# Altium schematic sheet MB1897.SchDoc(MB1897)
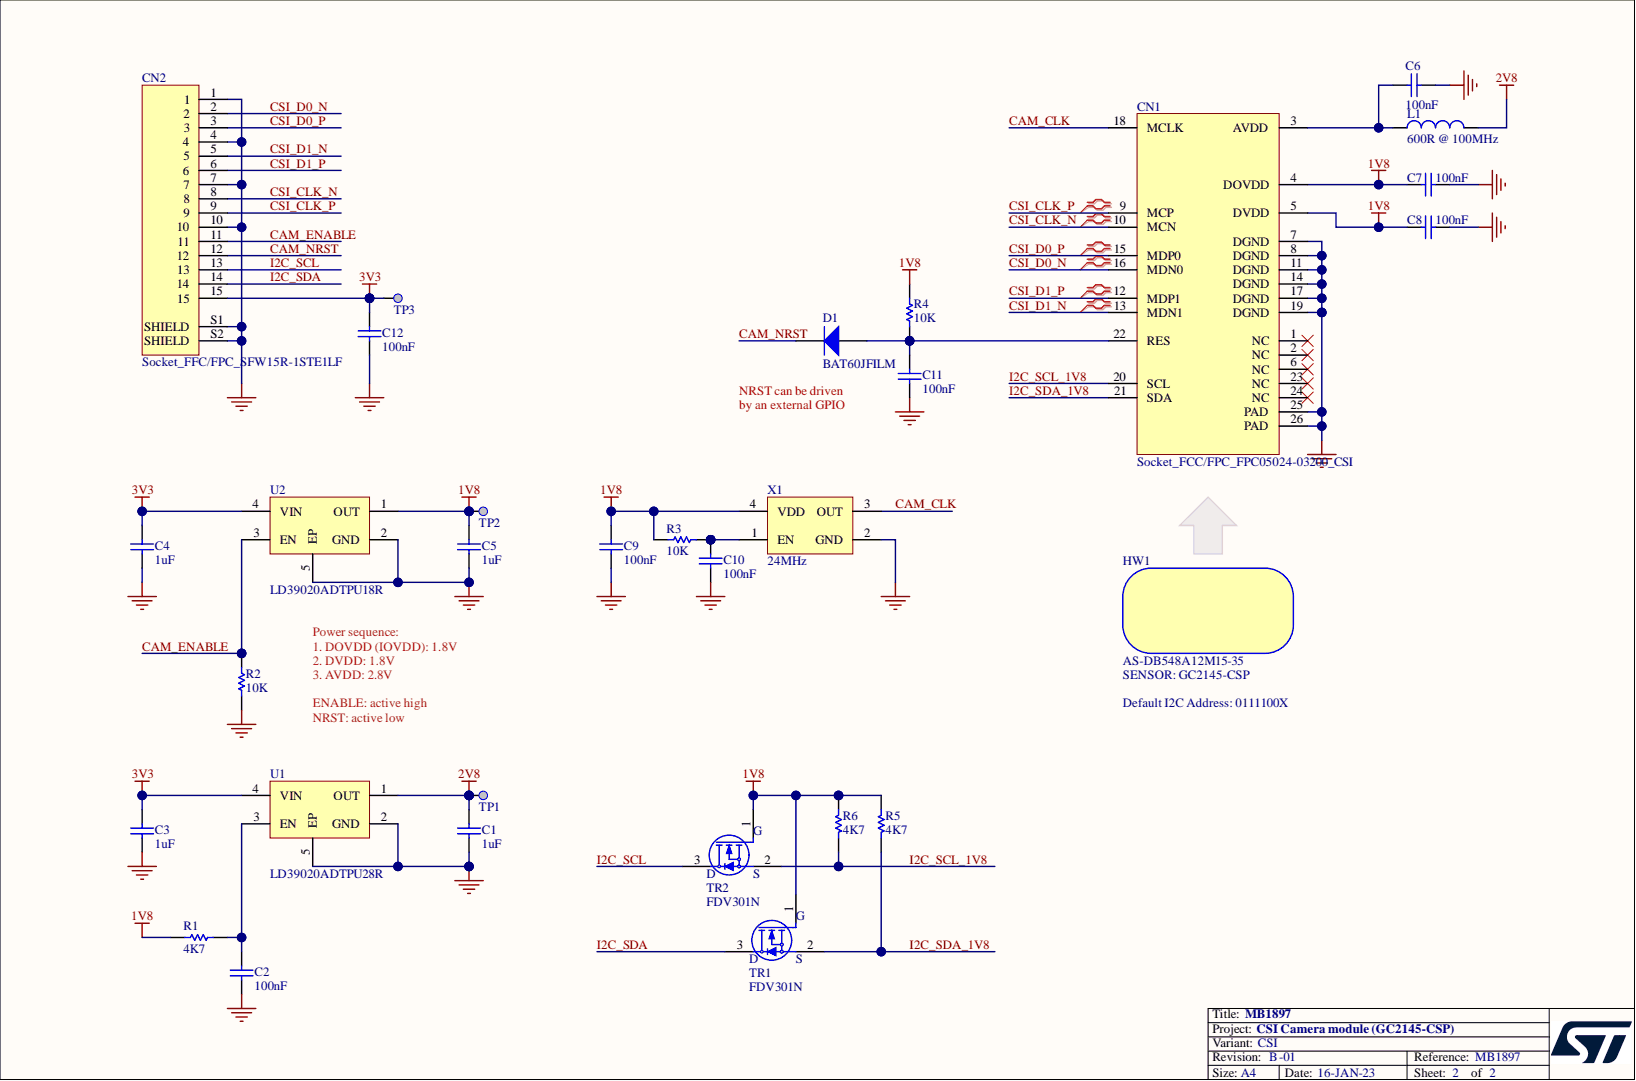
[diagram p0: MB1897.SchDoc]

## Parts
C1  value 1uF  [C0402]  (hidden comment: Capacitor)
C2  value 100nF  [C0402]  (hidden comment: Capacitor)
C3  value 1uF  [C0402]  (hidden comment: Capacitor)
C4  value 1uF  [C0402]  (hidden comment: Capacitor)
C5  value 1uF  [C0402]  (hidden comment: Capacitor)
C6  value 100nF  [C0402]  (hidden comment: Capacitor)
C7  value 100nF  [C0402]  (hidden comment: Capacitor)
C8  value 100nF  [C0402]  (hidden comment: Capacitor)
C9  value 100nF  [C0402]  (hidden comment: Capacitor)
C10  value 100nF  [C0402]  (hidden comment: Capacitor)
C11  value 100nF  [C0402]  (hidden comment: Capacitor)
C12  value 100nF  [C0402]  (hidden comment: Capacitor)
CN1  FPC05024-03200_CSI  value Socket_FCC/FPC_FPC05024-03200_CSI  [CON_ATOM_FPC05024-03200_INV]
CN2  SFW15R-1STE1LF  value Socket_FFC/FPC_SFW15R-1STE1LF  [CON_FCI_SFW15R-1STE1LF]
D1  BAT60JFILM  [DIO_SOD323]
HW1  value AS-DB548A12M15-35  Manufacturer_Part_Number: AS-DB548A12M15-35  (hidden comment: Camera_Module)
L1  value 600R @ 100MHz  [L0603]  (hidden comment: BEAD)
R1  value 4K7  [R0402]  (hidden comment: Resistor)
R2  value 10K  [R0402]  (hidden comment: Resistor)
R3  value 10K  [R0402]  (hidden comment: Resistor)
R4  value 10K  [R0402]  (hidden comment: Resistor)
R5  value 4K7  [R0402]  (hidden comment: Resistor)
R6  value 4K7  [R0402]  (hidden comment: Resistor)
TP1  Test Point  [TP_SMD_1MM]  (value not drawn)  (no BOM)
TP2  Test Point  [TP_SMD_1MM]  (value not drawn)  (no BOM)
TP3  Test Point  [TP_SMD_1MM]  (value not drawn)  (no BOM)
TR1  value FDV301N  Manufacturer_Part_Number: FDV301N  [MOS_SOT23]  (hidden comment: BSN20)
TR2  value FDV301N  Manufacturer_Part_Number: FDV301N  [MOS_SOT23]  (hidden comment: BSN20)
U1  LD39020  value LD39020ADTPU28R  Manufacturer_Part_Number: LD39020ADTPU28R  [REG_XDFN4-EPAD_CASE-711AJ_1X1_H0P4_P065]
U2  LD39020  value LD39020ADTPU18R  Manufacturer_Part_Number: LD39020ADTPU18R  [REG_XDFN4-EPAD_CASE-711AJ_1X1_H0P4_P065]
X1  value 24MHz  [CRY_NZ2016SH_4P]  (hidden comment: OSCILLATOR_4_PINS)

## Nets
1V8: C5.2 C7.1 C8.2 C9.2 CN1.4(DOVDD) CN1.5(DVDD) R1.1 R3.1 R4.2 R5.2 R6.2 TP2.1 TR1.1 TR2.1 U2.1(OUT) X1.4(VDD)
2V8: C1.2 L1.2 TP1.1 U1.1(OUT)
3V3: C3.2 C4.2 C12.2 CN2.15 TP3.1 U1.4(VIN) U2.4(VIN)
GND: C1.1 C2.1 C3.1 C4.1 C5.1 C6.2 C7.2 C8.1 C9.1 C10.1 C11.1 C12.1 CN1.7(DGND) CN1.8(DGND) CN1.11(DGND) CN1.14(DGND) CN1.17(DGND) CN1.19(DGND) CN1.25(PAD) CN1.26(PAD) CN2.1 CN2.4 CN2.7 CN2.10 CN2.S1(SHIELD) CN2.S2(SHIELD) R2.2 U1.2(GND) U1.5(EP) U2.2(GND) U2.5(EP) X1.2(GND)
CAM_CLK: CN1.18(MCLK) X1.3(OUT)
CAM_ENABLE: CN2.11 R2.1 U2.3(EN)
CAM_NRST: CN2.12 D1.2
CSI_CLK_N: CN1.10(MCN) CN2.8
CSI_CLK_P: CN1.9(MCP) CN2.9
CSI_D0_N: CN1.16(MDN0) CN2.2
CSI_D0_P: CN1.15(MDP0) CN2.3
CSI_D1_N: CN1.13(MDN1) CN2.5
CSI_D1_P: CN1.12(MDP1) CN2.6
I2C_SCL: CN2.13 TR2.3
I2C_SCL_1V8: CN1.20(SCL) R6.1 TR2.2
I2C_SDA: CN2.14 TR1.3
I2C_SDA_1V8: CN1.21(SDA) R5.1 TR1.2
NetC2_2: C2.2 R1.2 U1.3(EN)
NetC6_1: C6.1 CN1.3(AVDD) L1.1
NetC10_2: C10.2 R3.2 X1.1(EN)
NetC11_2: C11.2 CN1.22(RES) D1.1 R4.1

Not connected: CN1 1(NC) 2(NC) 6(NC) 23(NC) 24(NC)

## Library data of the parts
C1 C3-C5 = MCD-01722: Capacitor, MLCC, 1uF, 10V, X5R, +/-10%, 0402, T=0.5mm; Manufacturer: YAGEO; Manufacturer_Part_Number: CC0402KRX5R6BB105; Part_Preference: Recommended; Component_Country_Of_Origin: China; Database Table Name: MCD_PARTS$; ID: 3822; ShowFields: YES; Component Kind: Standard; ComponentLink1URL: http://tunmcdsvn.tun.st.com/Component_Documentation_Library/Trunk/Capacitor/YAGEO_X5R_Series; Internal_Part_Number: MCD-01722; Library Name: MCD_PARTS_database.DbLib; Order_Code_Digikey: 311-1439-1-ND; Order_Code_Farnell: 1458896; Order_Code_RS: N/A; Package: 0402; Part_Type: Capacitor; Status: Released
C2 C6-C12 = MCD-00006: Capacitor, MLCC, 100nF, 16V, X7R, +/-10%, 0402, T=0.5mm; Manufacturer: YAGEO; Manufacturer_Part_Number: CC0402KRX7R7BB104; Part_Preference: Recommended; Component_Country_Of_Origin: China; Database Table Name: MCD_PARTS$; ID: 2474; Order_Code_RS: 616-9701; ShowFields: YES; Component Kind: Standard; ComponentLink1URL: http://tunmcdsvn.tun.st.com/Component_Documentation_Library/Trunk/Capacitor/YAGEO_X7R_Series; Internal_Part_Number: MCD-00006; Library Name: MCD_PARTS_database.DbLib; Order_Code_Digikey: 311-1338-1-ND; Order_Code_Farnell: 9402047; Package: 0402; Part_Type: Capacitor; Status: Released
CN1 = MCD-02723: Socket_FFC/FPC, 24pins, 0.5mm, RA, SMD, T=2.0mm; Manufacturer: ATOM; Part_Preference: Recommended; Part_Type: Connector; Component_Country_Of_Origin: China; Database Table Name: MCD_PARTS$; ID: 4833; ShowFields: YES; Component Kind: Standard; ComponentLink1URL: http://tunmcdsvn.tun.st.com/Component_Documentation_Library/Trunk/Connector/ATOM_FPC05024-03200; Internal_Part_Number: MCD-02723; Library Name: MCD_PARTS_database.DbLib; Manufacturer_Part_Number: FPC05024-03200; Order_Code_Digikey: N/A; Order_Code_Farnell: N/A; Order_Code_RS: N/A; Status: Checked
CN2 = MCD-02259: Socket_FFC/FPC ZIF, 15pins, 1mm ,RA, SMD, T=2.7mm; Manufacturer: AMPHENOL ICC; Part_Preference: Recommended; Part_Type: Connector; Component_Country_Of_Origin: Malaysia; Database Table Name: MCD_PARTS$; ID: 4359; Order_Code_RS: 182-2728; ShowFields: YES; Component Kind: Standard; ComponentLink1URL: http://tunmcdsvn.tun.st.com/Component_Datasheet_Library/Trunk/Connectors/AMPHENOL_FFC,FPC_SFW15R-1STE1LF; Internal_Part_Number: MCD-02259; Library Name: MCD_PARTS_database.DbLib; Manufacturer_Part_Number: SFW15R-1STE1LF; Order_Code_Digikey: 609-1905-1-ND; Order_Code_Farnell: 2902867; Status: Checked
D1 = MCD-00034: BMS_Part_Number: 9151097; Manufacturer: STMICROELECTRONICS; Manufacturer_HQ_COO: EU; Part_Preference: Recommended; Part_Type: Diode; Component_Country_Of_Origin: China; Database Table Name: MCD_PARTS$; ID: 2483; ShowFields: YES; Component Kind: Standard; ComponentLink1URL: http://tunmcdsvn.tun.st.com/Component_Datasheet_Library/Trunk/Diodes/STM_Diode_BAT60JFILM-SOD323; Description: BAT60JFILM; Internal_Part_Number: MCD-00034; Library Name: MCD_PARTS_database.DbLib; Manufacturer_Part_Number: BAT60JFILM; Status: Released; Value: BAT60JFILM
HW1 = MCD-02713: Dual lane CSI Camera Module with GC2145-CSP sensor; Manufacturer: CMOS-CAMERA; Part_Preference: Recommended; Part_Type: Misc; Component_Country_Of_Origin: Unknown; Database Table Name: MCD_PARTS$; ID: 4819; ShowFields: YES; Component Kind: Standard; ComponentLink1URL: http://tunmcdsvn.tun.st.com/Component_Documentation_Library/Trunk/Misc/CMOS-CAMERA_AS-DB548A12M15-35; Internal_Part_Number: MCD-02713; Library Name: MCD_PARTS_database.DbLib; Order_Code_Digikey: N/A; Order_Code_Farnell: N/A; Order_Code_RS: N/A; Status: New
L1 = MCD-00047: Ferrite bead, 600R @1 00MHz, +/-25%, 350mA, 0R5, 0603, T=0.8mm; Manufacturer: TAI-TECH; Manufacturer_Part_Number: FCM1608KF-601T03; Part_Preference: Recommended; Part_Type: Inductor; Component_Country_Of_Origin: Unknown; Database Table Name: MCD_PARTS$; ID: 2546; ShowFields: YES; Component Kind: Standard; ComponentLink1URL: http://tunmcdsvn.tun.st.com/Component_Documentation_Library/Trunk/Inductor/TAI-TECH_FCM-1608_Series; Internal_Part_Number: MCD-00047; Library Name: MCD_PARTS_database.DbLib; Order_Code_Digikey: N/A; Order_Code_Farnell: N/A; Order_Code_RS: N/A; Package: 0603; Status: Released
R1 R5 R6 = MCD-00065: Resistor, 4K7, 0402, +/-1%, 1/16W; Manufacturer: YAGEO; Manufacturer_Part_Number: RC0402FR-074K7L; Part_Preference: Recommended; Component_Country_Of_Origin: China; Database Table Name: MCD_PARTS$; ID: 2526; ShowFields: YES; Component Kind: Standard; ComponentLink1URL: http://tunmcdsvn.tun.st.com/Component_Documentation_Library/Trunk/Resistor/YAGEO_RC_L_Series; Internal_Part_Number: MCD-00065; Library Name: MCD_PARTS_database.DbLib; Order_Code_Digikey: 311-4.7KLRCT-ND; Order_Code_Farnell: 9239316; Order_Code_RS: N/A; Package: 0402; Part_Type: Resistor; Status: Released
R2-R4 = MCD-00053: Resistor, 10K, 0402, +/-1%, 1/16W; BMS_Part_Number: 9131590; Manufacturer: YAGEO; Manufacturer_Part_Number: RC0402FR-0710KL; Part_Preference: Recommended; Component_Country_Of_Origin: China; Database Table Name: MCD_PARTS$; ID: 2539; ShowFields: YES; Component Kind: Standard; ComponentLink1URL: http://tunmcdsvn.tun.st.com/Component_Documentation_Library/Trunk/Resistor/YAGEO_RC_L_Series; Internal_Part_Number: MCD-00053; Library Name: MCD_PARTS_database.DbLib; Order_Code_Digikey: 311-10.0KLRCT-ND; Order_Code_Farnell: 9239359; Order_Code_RS: N/A; Package: 0402; Part_Type: Resistor; Status: Released
TP1-TP3 = MCD-00074: Test Point 1mm; Part_Preference: Recommended; Part_Type: Misc; Database Table Name: MCD_PARTS$; ID: 2518; ShowFields: YES; ComponentLink1URL: http://na; Internal_Part_Number: MCD-00074; Library Name: MCD_PARTS_database.DbLib; Manufacturer: N/A; Manufacturer_Part_Number: N/A; Order_Code_Digikey: N/A; Order_Code_Farnell: N/A; Order_Code_RS: N/A; Status: Released
TR1 TR2 = MCD-02156: MOSFET, N-Channel , Vds: 25V, Id: 0,22A, Vgs(th): 1.06V, Vgs(max): +/-8V, Rdson:5ohm@Vgs=2.7V, Qg=0,49nC; Manufacturer: ON SEMICONDUCTOR; Part_Preference: Recommended; Part_Type: Transistor; Component_Country_Of_Origin: China; Database Table Name: MCD_PARTS$; ID: 4256; ShowFields: YES; Component Kind: Standard; ComponentLink1URL: http://tunmcdsvn.tun.st.com/Component_Datasheet_Library/Trunk/Transistors/ON_SEMICONDUCTOR_MOSFET_FDN301N; Internal_Part_Number: MCD-02156; Library Name: MCD_PARTS_database.DbLib; Order_Code_Digikey: N/A; Order_Code_Farnell: N/A; Order_Code_RS: N/A; Package: SOT23-3; Status: Released
U1 = MCD-02223: LDO, output 2V8, 200 mA very low quiescent current; Manufacturer: STMICROELECTRONICS; Manufacturer_HQ_COO: EU; Part_Preference: Recommended; Part_Type: IC; Component_Country_Of_Origin: China; Database Table Name: MCD_PARTS$; ID: 4323; Order_Code_RS: NA; ShowFields: YES; Component Kind: Standard; ComponentLink1URL: http://tunmcdsvn.tun.st.com/Component_Datasheet_Library/Trunk/IC/STM_LDO_LD39020; Internal_Part_Number: MCD-02223; Library Name: MCD_PARTS_database.DbLib; Order_Code_Digikey: 497-19284-1-ND; Order_Code_Farnell: NA; Package: DFN4; Status: Checked
U2 = MCD-02224: LDO, output 1V8, 200 mA very low quiescent current; Manufacturer: STMICROELECTRONICS; Manufacturer_HQ_COO: EU; Part_Preference: Recommended; Part_Type: IC; Component_Country_Of_Origin: China; Database Table Name: MCD_PARTS$; ID: 4324; Order_Code_RS: NA; ShowFields: YES; Component Kind: Standard; ComponentLink1URL: http://tunmcdsvn.tun.st.com/Component_Datasheet_Library/Trunk/IC/STM_LDO_LD39020; Internal_Part_Number: MCD-02224; Library Name: MCD_PARTS_database.DbLib; Order_Code_Digikey: 497-15493-1-ND; Order_Code_Farnell: NA; Package: DFN4; Status: Checked
X1 = MCD-01627: Oscillator, SPXO, 24MHz, +/-50ppm, 3V3, square wave, 2x1.6mm; BMS_Part_Number: 9157051; Manufacturer: NDK; Manufacturer_Part_Number: NZ2016SH-24MHZ-END4869F; Part_Preference: Recommended; Part_Type: Crystal_Osc; Component_Country_Of_Origin: Unknown; Database Table Name: MCD_PARTS$; ID: 3727; ShowFields: YES; Component Kind: Standard; ComponentLink1URL: http://tunmcdsvn.tun.st.com/Component_Documentation_Library/Trunk/Crytal_Oscillator/NDK_NZ2016SH_Series; Internal_Part_Number: MCD-01627; Library Name: MCD_PARTS_database.DbLib; Order_Code_Digikey: N/A; Order_Code_Farnell: N/A; Order_Code_RS: N/A; Package: 2x1.6mm; Status: Released
